# Altium schematic sheet Power Supply.SchDoc
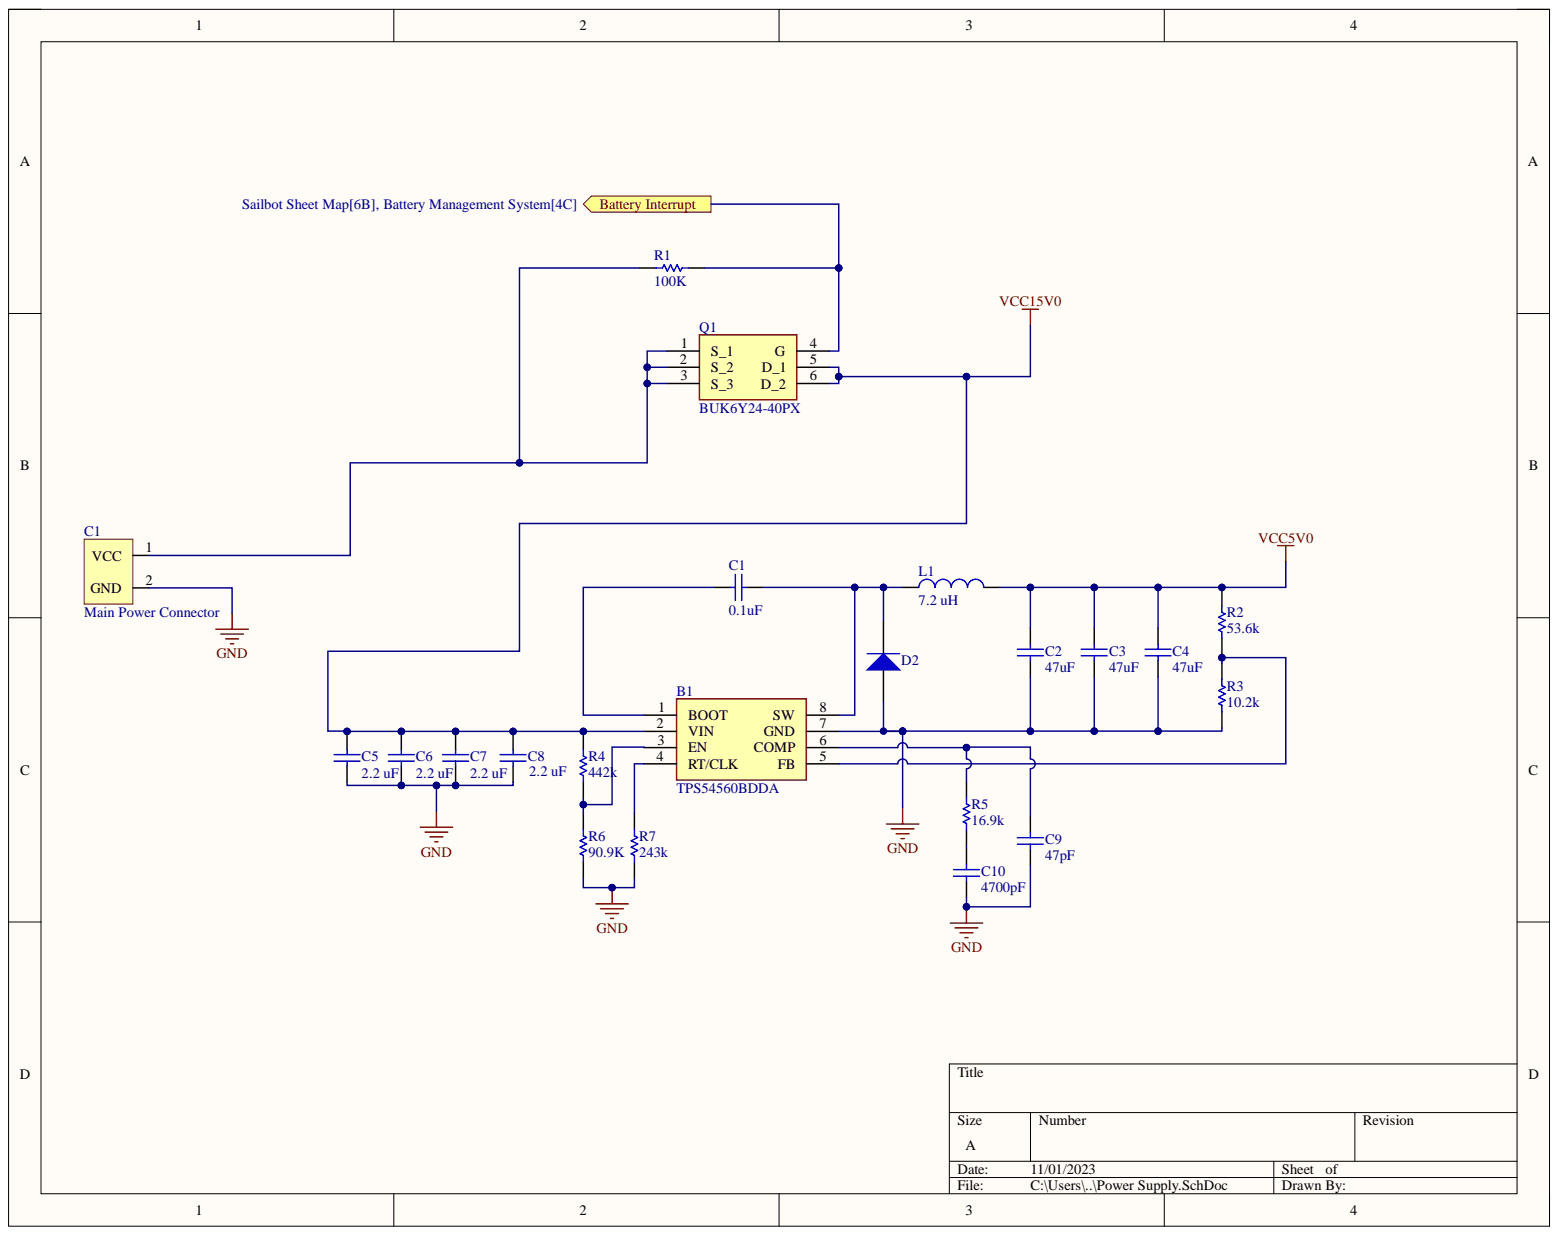
[diagram p0: Power Supply.SchDoc]

## Parts
B1  TPS54560BDDA  [SOIC127P600X170-8N]
C1  Main Power Connector
C1  value 0.1uF  (hidden comment: Capacitor)
C2  value 47uF  (hidden comment: Capacitor)
C3  value 47uF  (hidden comment: Capacitor)
C4  value 47uF  (hidden comment: Capacitor)
C5  Capacitor  (value not drawn)
C6  value 2.2 uF  (hidden comment: Capacitor)
C7  value 2.2 uF  (hidden comment: Capacitor)
C8  value 2.2 uF  (hidden comment: Capacitor)
C9  value 47pF  (hidden comment: Capacitor)
C10  value 4700pF  (hidden comment: Capacitor)
D2  Diode  (value not drawn)
L1  value 7.2 uH  (hidden comment: Inductor)
Q1  BUK6Y24-40PX  [BUK6Y2440PX]
R1  value 100K  (hidden comment: Resistor)
R2  value 53.6k  (hidden comment: Resistor)
R3  value 10.2k  (hidden comment: Resistor)
R4  value 442k  (hidden comment: Resistor)
R5  value 16.9k  (hidden comment: Resistor)
R6  value 90.9K  (hidden comment: Resistor)
R7  value 243k  (hidden comment: Resistor)

## Ports
Battery Interrupt

## Nets
GND: B1.7(GND) C1.2 C2.1 C3.1 C4.1 C5.1 C6.1 C7.1 C8.1 C9.1 C10.1 D2.1 R3.1 R6.1 R7.1
VCC5V0: C2.2 C3.2 C4.2 L1.2 R2.2
VCC15V0: B1.2(VIN) C5.2 C6.2 C7.2 C8.2 Q1.5(D_1) Q1.6(D_2) R4.2
Battery Interrupt: Q1.4(G) R1.2 ; port Battery Interrupt
NetB1_1: B1.1(BOOT) C1.1
NetB1_3: B1.3(EN) R4.1 R6.2
NetB1_4: B1.4(RT/CLK) R7.2
NetB1_5: B1.5(FB) R2.1 R3.2
NetB1_6: B1.6(COMP) C9.2 R5.2
NetB1_8: B1.8(SW) C1.2 D2.2 L1.1
NetC1_1: C1.1 Q1.1(S_1) Q1.2(S_2) Q1.3(S_3) R1.1
NetC10_2: C10.2 R5.1

## Library data of the parts
B1 = TPS54560BDDA: Switching Voltage Regulators A 595-TPS54560BDDAR; Manufacturer_Name: Texas Instruments; Geometry.Height: 1.7mm; Component Kind: Standard; Datasheet Link: http://www.ti.com/lit/ds/symlink/tps54560b.pdf; Manufacturer_Part_Number: TPS54560BDDA; Mouser Part Number: 595-TPS54560BDDA; Mouser Price/Stock: https://www.mouser.co.uk/ProductDetail/Texas-Instruments/TPS54560BDDA?qs=gZXFycFWdAMqSdZZ7nACGQ%3D%3D; Library Name: Sailbot Library.SchLib
C1-C4 C6-C10 = Capacitor: SimulationGenericComponent: True; Component Kind: Standard; Description: Capacitor; Library Name: Simulation Generic Components.IntLib; Simulation: C_Capacitor_Default
C5 = Capacitor: SimulationGenericComponent: True; Value: 2.2 uF; Component Kind: Standard; Description: Capacitor; Library Name: Simulation Generic Components.IntLib; Simulation: C_Capacitor_Default
D2 = Diode: AF: 1; BV: 0; BV_MAX: 1e99; EG: 1.11; FC: 0.5; IBV: 0.001; IS: 1e-14; MJSW: 0.33; NTUN: 30; SimulationGenericComponent: True; TNOM: 27; XTI: 3; CJO: 0; CJP: 0; Component Kind: Standard; CTA: 0; CTP: 0; Description: Diode; FCS: 0.5; FV_MAX: 1e99; IKF: 0; IKR: 0; ISR: 1e-14; JSW: 0; JTUN: 0; JTUNSW: 0; KEG: 1; KF: 0; LEVEL: 1; Library Name: Simulation Generic Components.IntLib; N: 1; NBV: 1; NR: 1; NS: 1; PHP: 1; RS: 0; Simulation: diode; TCV: 0; TLEV: 0; TLEVC: 0; TM1: 0; TM2: 0; TPB: 0; TPHP: 0; TRS: 0; TRS2: 0; TT: 0; TTT1: 0; TTT2: 0; VJ: 1; XTITUN: 3
L1 = Inductor: SimulationGenericComponent: True; Component Kind: Standard; Description: Inductor; Library Name: Simulation Generic Components.IntLib; Simulation: L_Inductor_Default
Q1 = BUK6Y24-40PX: NEXPERIA - BUK6Y24-40PX - Power MOSFET, P Channel, 40 V, 39 A, 0.019 ohm, LFPAK56, Surface Mount; Geometry.Height: 1.2mm; Component Kind: Standard; Datasheet Link: https://assets.nexperia.com/documents/data-sheet/BUK6Y24-40P.pdf; Manufacturer_Name: Nexperia; Manufacturer_Part_Number: BUK6Y24-40PX; Mouser Part Number: 771-BUK6Y24-40PX; Mouser Price/Stock: https://www.mouser.co.uk/ProductDetail/Nexperia/BUK6Y24-40PX?qs=vmHwEFxEFR8tyd2WwTEwBg%3D%3D; Library Name: Sailbot Library.SchLib
R1-R7 = Resistor: SimulationGenericComponent: True; TC1: 0; Component Kind: Standard; Description: Resistor; Library Name: Simulation Generic Components.IntLib; Simulation: R_Resistor_Default; TC2: 0
C1 = Main Power Connector: Component Kind: Standard; Library Name: Sailbot Library.SchLib
